# Altium schematic sheet rpi_shield.SchDoc
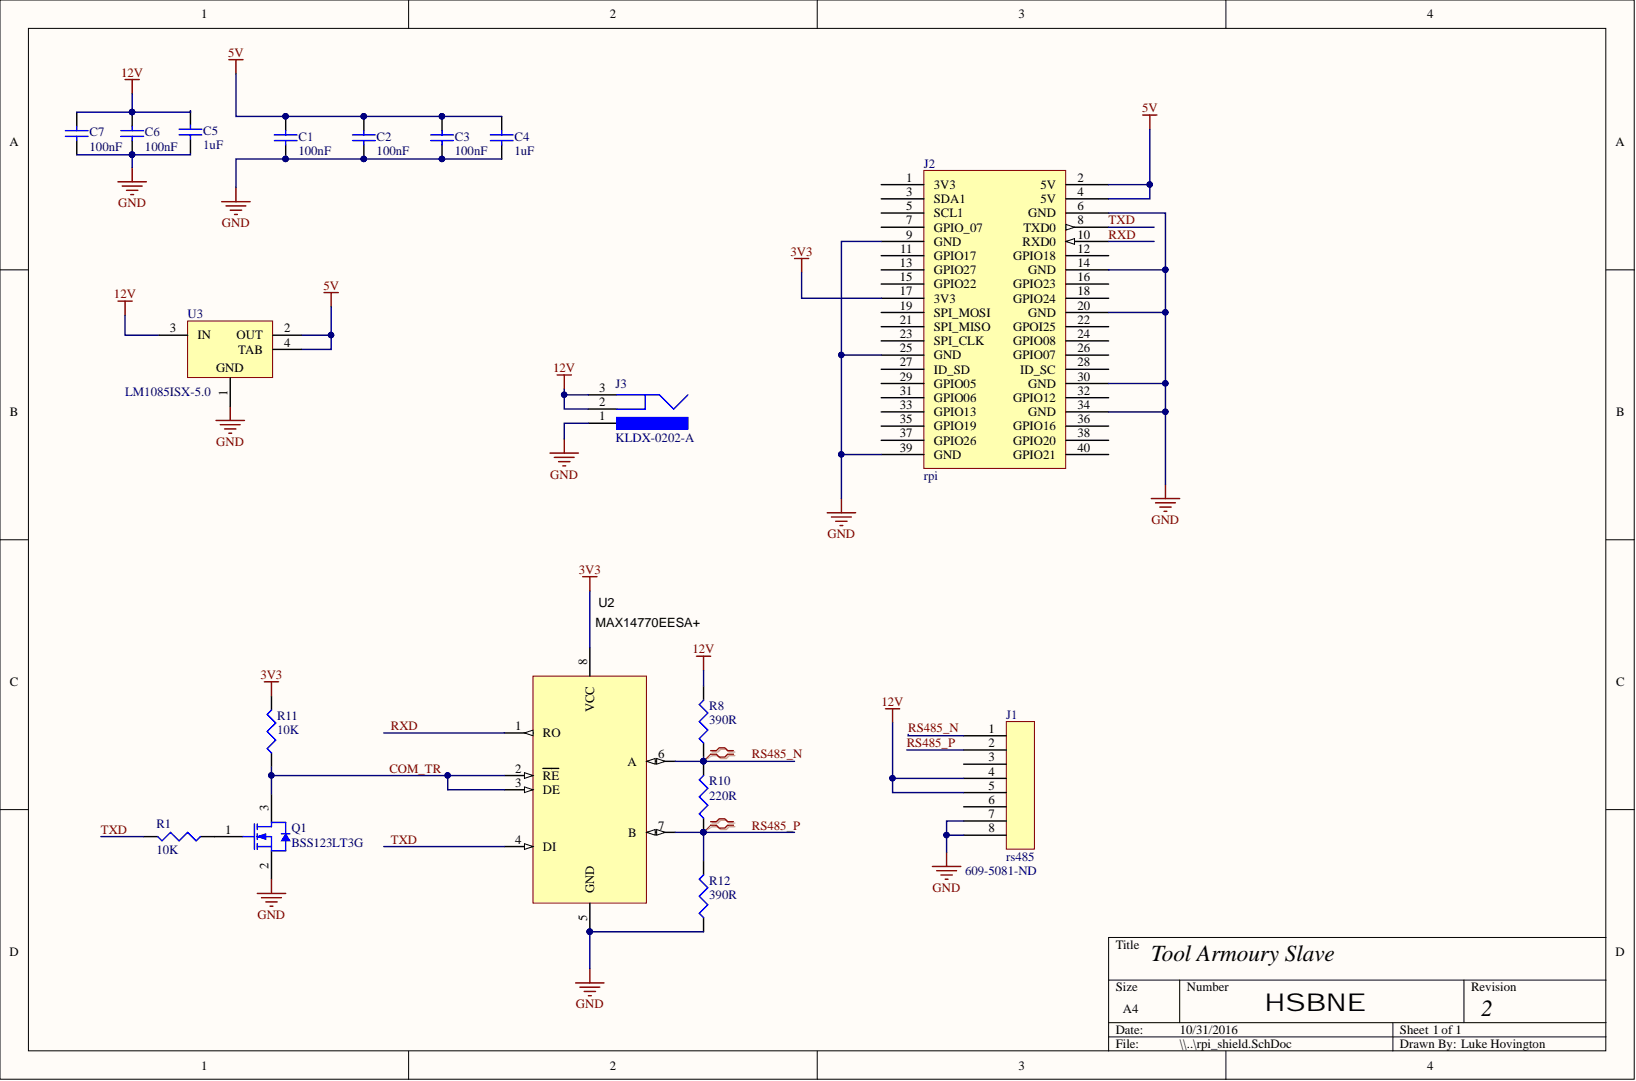
[diagram p0: rpi_shield.SchDoc]

## Parts
C1  100nF  [C1206]
C2  100nF  [C1206]
C3  100nF  [C1206]
C4  1uF  [C1206]
C5  1uF  [C1206]
C6  100nF  [C1206]
C7  100nF  [C1206]
J1  rs485  Supplier Part Number 1: 609-5081-ND  [RJ45]
J2  rpi  [2x20]
J3  KLDX-0202-A  [KYCN-KLDX-0202-A_V]
Q1  BSS123LT3G  [ONSC-SOT-23-3-318-08_V]
R1  10K  [C1206]
R8  390R  [C1206]  (no BOM)
R10  220R  [C1206]  (no BOM)
R11  10K  [C1206]
R12  390R  [C1206]  (no BOM)
U2  MAX14770EESA+  [8S1_L]
U3  LM1085ISX-5.0  [TS3B_L]

## Nets
3V3: J2.17(3V3) R11.2 U2.8(VCC)
5V: C1.2 C2.2 C3.2 C4.2 J2.2(5V) J2.4(5V) U3.2(OUT) U3.4(TAB)
12V: C5.2 C6.2 C7.2 J1.4 J1.5 J3.2 J3.3 R8.2 U3.3(IN)
GND: C1.1 C2.1 C3.1 C4.1 C5.1 C6.1 C7.1 J1.7 J1.8 J2.6(GND) J2.9(GND) J2.14(GND) J2.20(GND) J2.25(GND) J2.30(GND) J2.34(GND) J2.39(GND) J3.1 Q1.2 R12.1 U2.5(GND) U3.1
COM_TR: Q1.3 R11.1 U2.2(RE) U2.3(DE)
RS485_N: J1.1 R8.1 R10.2 U2.6(A)
RS485_P: J1.2 R10.1 R12.2 U2.7(B)
RXD: J2.10(RXD0) U2.1(RO)
TXD: J2.8(TXD0) R1.2 U2.4(DI)
NetQ1_1: Q1.1 R1.1

Not connected: J1 3 6; J2 1(3V3) 3(SDA1) 5(SCL1) 7(GPIO_07) 11(GPIO17) 12(GPIO18) 13(GPIO27) 15(GPIO22) 16(GPIO23) 18(GPIO24) 19(SPI_MOSI) 21(SPI_MISO) 22(GPOI25) 23(SPI_CLK) 24(GPIO08) 26(GPIO07) 27(ID_SD) 28(ID_SC) 29(GPIO05) 31(GPIO06) 32(GPIO12) 33(GPIO13) 35(GPIO19) 36(GPIO16) 37(GPIO26) 38(GPIO20) 40(GPIO21)

## Library data of the parts
C1-C3 C6 C7 = Cap 100nF: Capacitor (Semiconductor SIM Model); Component Kind: Standard; LatestRevisionDate: 29-May-2009; LatestRevisionNote: IPC-7351 Footprint Added.; PackageDescription: Chip Capacitor; PackageReference: C1206; Published: 8-Jun-2000; Signal Integrity: Cap Semi; Simulation: CAP; Supplier 1: Digi-Key; Supplier 2: Mouser; Supplier Part Number 1: 399-1249-1-ND; Supplier Part Number 2: 80-C1206C104K5R; Value: 100nF; Library Name: ToolDoorController.SCHLIB; Publisher: Altium Limited
C4 C5 = Cap 1uF: Capacitor (Semiconductor SIM Model); Component Kind: Standard; LatestRevisionDate: 29-May-2009; LatestRevisionNote: IPC-7351 Footprint Added.; PackageDescription: Chip Capacitor; PackageReference: C1206; Published: 8-Jun-2000; Signal Integrity: Cap Semi; Simulation: CAP; Supplier 1: Mouser; Supplier 2: Digi-Key; Supplier Part Number 1: 80-C1206C105K5R; Supplier Part Number 2: 399-8150-1-ND; Value: 1uF; Library Name: ToolDoorController.SCHLIB; Publisher: Altium Limited
J1 = rj45: Component Kind: Standard; Description: rj45; Supplier 1: Digi-Key; Library Name: ToolDoorController.SCHLIB
J2 = rpi2: RaspPi I/O header; Component Kind: Standard; Library Name: rpi_shield.SCHLIB
J3 = CMP-1673-00001-5: DC Power Jack, 24 V, 3.5 A, -25 to 85 degC, 3-Pin THD, RoHS; Manufacturer: Kycon; Current Rating: 3.5 A; Voltage Rating: 24 V; Colour: Black; Component Kind: Standard; ComponentLink1Description: Manufacturer URL; ComponentLink1URL: http://www.kycon.com/; ComponentLink2Description: Datasheet; ComponentLink2URL: http://www.kycon.com/Pub_Eng_Draw/KLDX-0202-X.PDF; Connector Type: Power; Contact Gender: Female; Contact Material: Brass; Contact Plating: Tin; DatasheetVersion: Rev. D3, 06/2013; Height: 10.8 mm; Housing Material: PBT; Length: 9.2 mm; Library Name: Altium Content Vault; Max Operating Temperature: 85 degC; Min Operating Temperature: -25 degC; Mount: Through Hole; Mounting Technology: Through Hole; PackageDescription: 3-Pin Through Hole Device, Body 14.5 x 9 mm; Package Quantity: 500; PackageReference: KLDX-0202-A; Pins: 2; RoHSCompliant: true
Q1 = CMP-1058-00787-1: Power MOSFET, 170 mA, 100 V, N-Channel, 3-Pin SOT-23, Pb-Free, Tape and Reel; Manufacturer: On Semiconductor; Channel Polarity: N Channel; Ciss Typ (pF): 20; Configuration: Single; Coss Typ (pF): 9; Crss Typ (pF): 4; ID Max (A): 0.17; PD Max (W): 0.225; V(BR)DSS Min (V): 100; VGS(th) Max (V): 2.6; Component Kind: Standard; ComponentLink1Description: Manufacturer URL; ComponentLink1URL: http://www.onsemi.com/; ComponentLink2Description: Datasheet; ComponentLink2URL: http://www.onsemi.com/pub_link/Collateral/BSS123LT1-D.PDF; ComponentLink3Description: Package Specification; ComponentLink3URL: http://www.onsemi.cn/pub_link/Collateral/318-08.PDF; DatasheetVersion: Rev. 8; Library Name: Altium Content Vault; Mounting Technology: Surface Mount; PackageDescription: 3-Pin Small Outline Transistor, Body 2.92 x 1.3 mm, Pitch 0.95 mm; PackageReference: SOT-23-3-318-08; Package Type: SOT-23-3; PackageVersion: Rev. AP, 11/2009; Packing: Tape and Reel; PartNumber: BSS123LT3G; RoHS: Pb-Free; VGS Max (V): 20
R1 R11 = Resistor-10K: Resistor; Component Kind: Standard; LatestRevisionDate: 29-May-2009; LatestRevisionNote: IPC-7351 Footprint Added.; PackageDescription: Chip Resistor; PackageReference: J1-0603; Published: 8-Jun-2000; Signal Integrity: Res; Simulation: RESISTOR; Supplier 1: Digi-Key; Supplier 2: Mouser; Supplier Part Number 1: P10KECT-ND; Supplier Part Number 2: 71-CRCW1206-10K-E3; Value: 10K; Library Name: ToolDoorController.SCHLIB; Publisher: Altium Limited
R8 R12 = Resistor-390R: Resistor; LatestRevisionDate: 29-May-2009; LatestRevisionNote: IPC-7351 Footprint Added.; PackageDescription: Chip Resistor; PackageReference: J1-0603; Published: 8-Jun-2000; Signal Integrity: Res; Simulation: RESISTOR; Supplier 1: Digi-Key; Supplier Part Number 1: RMCF1206JT390RCT-ND; Value: 390R; Library Name: ToolDoorController.SCHLIB; Publisher: Altium Limited
R10 = Resistor-220R: Resistor; LatestRevisionDate: 29-May-2009; LatestRevisionNote: IPC-7351 Footprint Added.; PackageDescription: Chip Resistor; PackageReference: J1-0603; Published: 8-Jun-2000; Signal Integrity: Res; Simulation: RESISTOR; Supplier 1: Digi-Key; Supplier Part Number 1: RMCF1206JT220RCT-ND; Value: 220R; Library Name: ToolDoorController.SCHLIB; Publisher: Altium Limited
U2 = MAX14770EESA+: TXRX PROFIBUS RS-485 8-SOIC; Component Kind: Standard; MPN: MAX14770EESA+; Supplier 1: Mouser; Supplier 2: Digi-Key; Supplier Part Number 1: 700-MAX14770EESA+; Supplier Part Number 2: MAX14770EESA+-ND; Library Name: ToolDoorController.SCHLIB
U3 = CMP-0062-00621-3: 3A Low Dropout Positive Regulators, 3-pin TO-263; Manufacturer: National Semiconductor; CompType: LDO IC; High-Reliability: Y; PackageTopMarking: NSUZXYTTE#*LM1085*IS-5.0; PowerWise: N; Code_JEDEC: MO-169-AA; Component Kind: Standard; ComponentLink1Description: Manufacturer URL; ComponentLink1URL: http://www.national.com/; ComponentLink2Description: LM1085 Datasheet; ComponentLink2URL: http://www.national.com/ds/LM/LM1085.pdf; ComponentLink3Description: LM1085 Web Page; ComponentLink3URL: http://www.national.com/pf/LM/LM1085.html; ComponentLink4Description: TS3B Package Page; ComponentLink4URL: http://www.national.com/packaging/folders/ts3b.html; DatasheetVersion: Jun-2005; Library Name: Altium Content Vault; PackageDescription: TO-263, Molded, .400 x .390 x .180in, 3 Lead, .1in Pitch, Surface Mount; PackageReference: TS3B; PackageVersion: revF, Apr-2005; PartNumber: LM1085ISX-5.0; PinCount: 3; RevisionNote: SCH symbol layout adjusted; RoHS: N
